# Altium schematic sheet PMIC.SchDoc
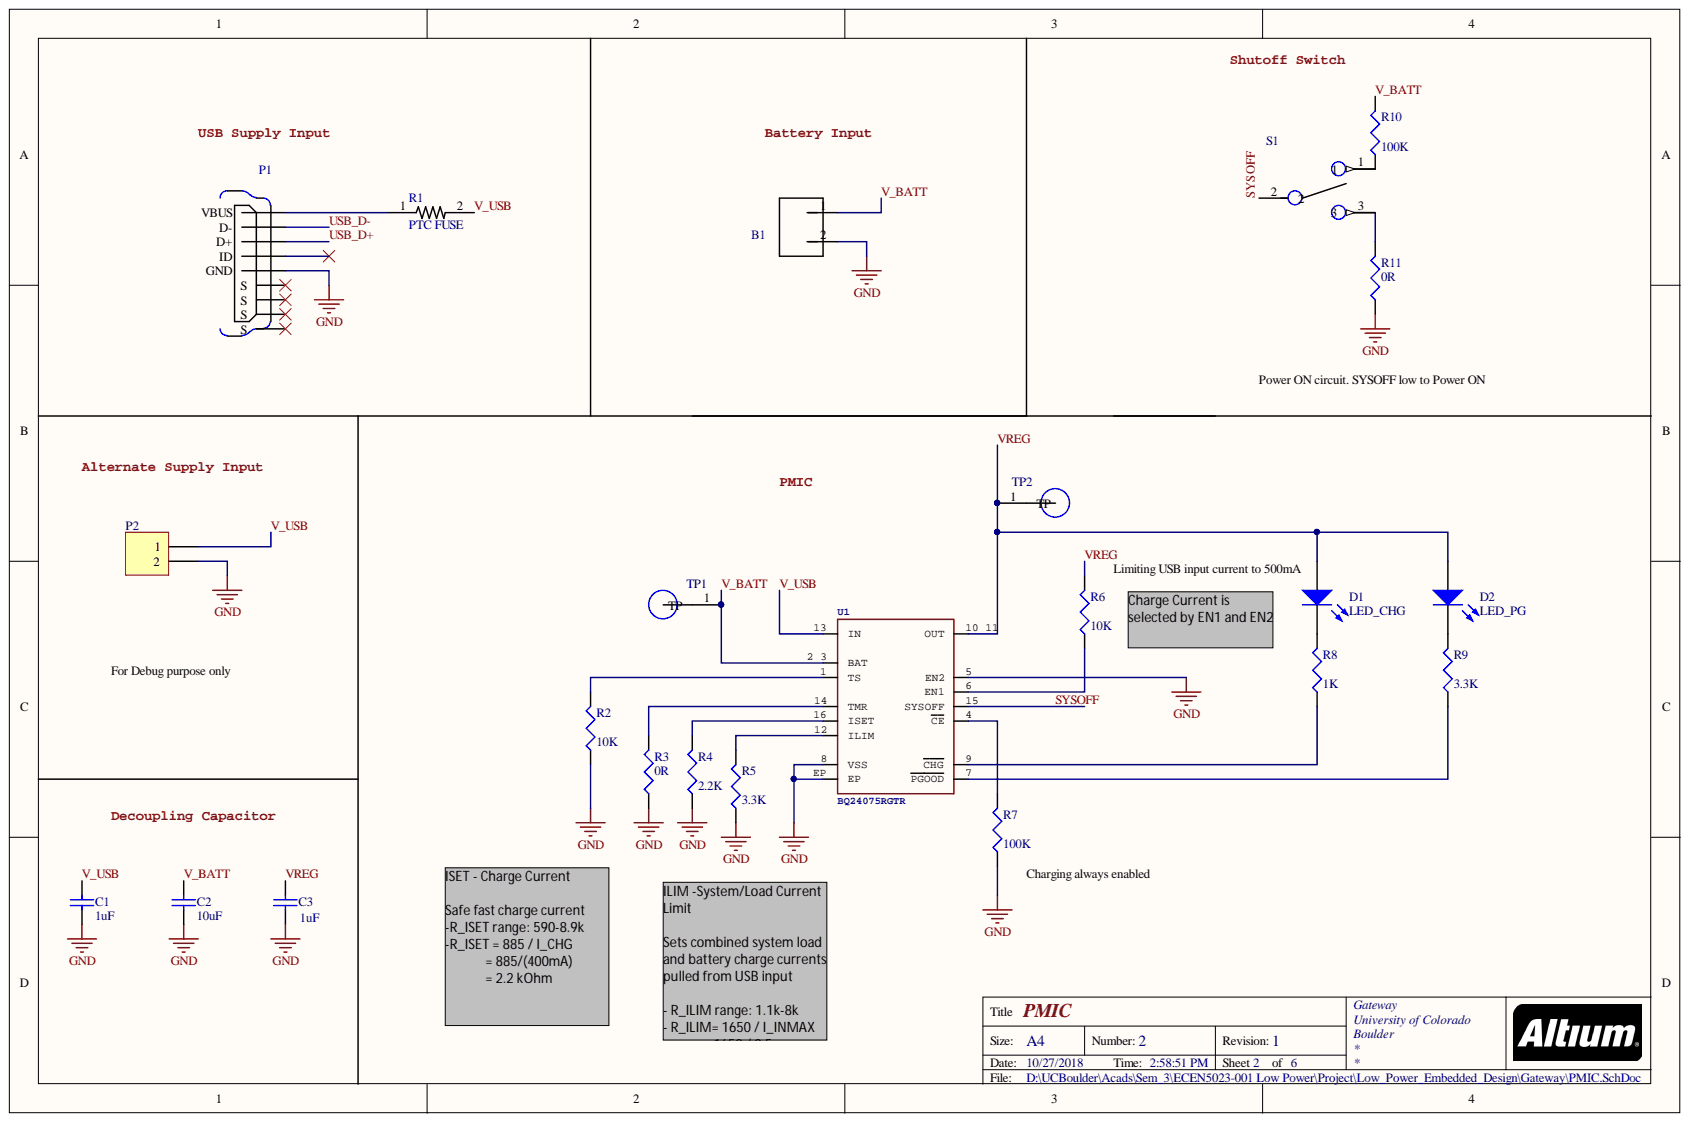
[diagram p0: PMIC.SchDoc]

## Parts
B1  S2B-PH-SM4-TB(LF)(SN)  [S2B-PH-SM4-TB(LF)(SN)]  (value not drawn)
C1  value 1uF  [1608[0603]]  (hidden comment: GCM188R71E104KA57D)
C2  value 10uF  [1608[0603]]  (hidden comment: GRM188R61A106KE69D)
C3  value 1uF  [1608[0603]]  (hidden comment: GCM188R71E104KA57D)
D1  LED_CHG  [3.2X1.6X1.1]
D2  LED_PG  [3.2X1.6X1.1]
P1  10104111-0001LF  [FRAMATOME_10104111-0001LF]  (value not drawn)
P2  Manufacturer Part Number  [HDR1X2]  (value not drawn)
R1  PTC FUSE  [RESC3216X125N]
R2  value 10K  [1608[0603]]  (hidden comment: MCT06030C1002FP500)
R3  value 0R  [1608[0603]]  (hidden comment: MCT0603MZ0000ZP500)
R4  value 2.2K  [1608[0603]]  (hidden comment: ERJ-3EKF2201V)
R5  value 3.3K  [1608[0603]]  (hidden comment: ERJ-3GEYJ332V)
R6  value 10K  [1608[0603]]  (hidden comment: MCT06030C1002FP500)
R7  value 100K  [1608[0603]]  (hidden comment: ERJ-3GEYJ332V)
R8  value 1K  [1608[0603]]  (hidden comment: MCT06030C1001FP500)
R9  value 3.3K  [1608[0603]]  (hidden comment: ERJ-3GEYJ332V)
R10  value 100K  [1608[0603]]  (hidden comment: ERJ-3GEYJ332V)
R11  value 0R  [1608[0603]]  (hidden comment: MCT0603MZ0000ZP500)
S1  AYZ0102AGRLC  [SW_AYZ0102AGRLC]  (value not drawn)
TP1  5019  [TP380X203]  (value not drawn)
TP2  5019  [TP380X203]  (value not drawn)
U1  BQ24075RGTR  [QFN50P300X300X100-17N]

## Nets
GND: B1.2 C1.1 C2.2 C3.1 P1.5 P2.2 R2.1 R3.1 R4.1 R5.1 R7.1 R11.1 U1.5(EN2) U1.8(VSS) U1.EP
SYSOFF: S1.2 U1.15(SYSOFF)
USB_D+: P1.3(D+)
USB_D-: P1.2(D-)
V_BATT: B1.1 C2.1 R10.2 TP1.1 U1.2 3(BAT)
V_USB: C1.2 P2.1 R1.2 U1.13(IN)
VREG: C3.2 D1.1 D2.1 R6.2 TP2.1 U1.10 11(OUT)
NetD1_2: D1.2 R8.2
NetD2_2: D2.2 R9.2
NetP1_1: P1.1 R1.1
NetR2_2: R2.2 U1.1(TS)
NetR3_2: R3.2 U1.14(TMR)
NetR4_2: R4.2 U1.16(ISET)
NetR5_2: R5.2 U1.12(ILIM)
NetR6_1: R6.1 U1.6(EN1)
NetR7_2: R7.2 U1.4(CE)
NetR8_1: R8.1 U1.9(CHG)
NetR9_1: R9.1 U1.7(PGOOD)
NetR10_1: R10.1 S1.1
NetR11_2: R11.2 S1.3

Not connected: P1 4(ID) 6(S) 7(S) 8(S) 9(S)

## Library data of the parts
B1 = S2B-PH-SM4-TB(LF)(SN): CONN HEADER PH SIDE 2POS 2MM SMD; Manufacturer: JST Sales America Inc.; Component Kind: Standard; ComponentLink1Description: Digi-Key URL; ComponentLink1URL: https://www.digikey.com/products/en/connectors-interconnects/rectangular-connectors-headers-male-pins/314?k=S2B-PH-SM4-TB%28LF%29%28SN%29&k=&pkeyword=S2B-PH-SM4-TB%28LF%29%28SN%29&pv7=2&sf=0&quantity=&ColumnSort=0&page=1&pageSize=25; Digi-Key Part Number: 455-1749-1-ND; Library Name: S2B-PH-SM4-TB_LF__SN_.SchLib; Manufacturer Part Number: S2B-PH-SM4-TB(LF)(SN)
C1 C3 = Cap Semi: CAP CER 0.1UF 25V X7R 0603; Manufacturer: Murata Electronics North America; Component Kind: Standard; ComponentLink1Description: Digi-Key Link; ComponentLink1URL: https://www.digikey.com/product-detail/en/murata-electronics-north-america/GCM188R71E104KA57D/490-6051-1-ND/3845251; Digi-Key Part Number: 490-6051-1-ND; Library Name: Miscellaneous Devices.IntLib; Manufacturer Part Number: GCM188R71E104KA57D; Signal Integrity: Cap Semi; Simulation: CAP
C2 = Cap: CAP CER 10UF 10V X5R 0603; Manufacturer: Murata Electronics North America; Component Kind: Standard; ComponentLink1Description: Digi-Key Link; ComponentLink1URL: https://www.digikey.com/product-detail/en/murata-electronics-north-america/GRM188R61A106KE69D/490-10474-1-ND/5026392; Digi-Key Part Number: 490-10474-1-ND; LatestRevisionDate: 17-Jul-2002; LatestRevisionNote: Re-released for DXP Platform.; Library Name: Miscellaneous Devices.IntLib; Manufacturer Part Number: GRM188R61A106KE69D; PackageDescription: Radial Cap, Thru-Hole; 2 Leads; 0.3 in Pin Spacing; PackageReference: RAD-0.3; Published: 8-Jun-2000; Signal Integrity: Cap; Simulation: CAP; Publisher: Altium Limited
D1 = LED2: Blue 470nm LED Indication - Discrete 2.85V 0603 (1608 Metric); Manufacturer: OSRAM Opto Semiconductors Inc.; Manufacturer Part Number: LB Q39G-L2OO-35-1; Component Kind: Standard; ComponentLink1Description: Digi-Key Link; ComponentLink1URL: https://www.digikey.com/product-detail/en/osram-opto-semiconductors-inc/LB-Q39G-L2OO-35-1/475-2816-1-ND/2176355; Digi-Key Part Number: 475-2816-1-ND; Library Name: Miscellaneous Devices.IntLib; Simulation: LED2
D2 = LED2: LED GREEN DIFFUSED 0603 SMD; Manufacturer: OSRAM Opto Semiconductors Inc.; Manufacturer Part Number: LG L29K-F2J1-24-Z; Component Kind: Standard; ComponentLink1Description: Digi-Key Link; ComponentLink1URL: https://www.digikey.com/product-detail/en/osram-opto-semiconductors-inc/LG-L29K-F2J1-24-Z/475-3118-1-ND/4899549; Digi-Key Part Number: 475-3118-1-ND; Library Name: Miscellaneous Devices.IntLib; Simulation: LED2
P1 = 10104111-0001LF: Micro Usb, 2.0 Type Ab, Receptacle, Th; Manufacturer: Amphenol FCI; Component Kind: Standard; ComponentLink1Description: Digi-Key Link; ComponentLink1URL: https://www.digikey.com/product-detail/en/amphenol-fci/10104111-0001LF/609-4053-1-ND/2350359?utm_source=snapeda&utm_medium=aggregator&utm_campaign=symbol; Digi-Key Part Number: 609-4053-1-ND; Library Name: USB-connector.SchLib; Manufacturer Part Number: 10104111-0001LF
P2 = Header 2: Header, 2-Pin; Component Kind: Standard; Library Name: Miscellaneous Connectors.IntLib; Signal Integrity: Connector
R1 = 0ZCA0075FF2G: PTC RESET FUSE 6V 500MA 1206; Manufacturer: Bel Fuse; Manufacturer Part Number: Littelfuse Inc.; Availability: Available; Component Kind: Standard; ComponentLink1Description: Digi-Key Link; ComponentLink1URL: https://www.digikey.com/product-detail/en/littelfuse-inc/1206L050YR/F2112CT-ND/455721; Digi-Key Part Number: F2112CT-ND; Library Name: PTC-1206.SchLib; MP: 0ZCA0075FF2G; Package: 1206 Bel Fuse; Price: None; SnapEDA_Link: https://www.snapeda.com/parts/0ZCA0075FF2G/Bel%20Fuse%20Inc./view-part/?ref=snap
R2 R6 = Res3: RES SMD 10K OHM 1% 1/8W 0603; Manufacturer: Vishay Beyschlag; Component Kind: Standard; ComponentLink1Description: Digi-Key URL; ComponentLink1URL: https://www.digikey.com/products/en?keywords=MCT0603-10.0K-CFCT-ND; Digi-Key Part Number: MCT0603-10.0K-CFCT-ND; Library Name: Miscellaneous Devices.IntLib; Manufacturer Part Number: MCT06030C1002FP500; Signal Integrity: Res; Simulation: RESISTOR
R3 R11 = Res3: RES 0 OHM JUMPER 1/8W 0603; Manufacturer: Vishay Beyschlag; Component Kind: Standard; ComponentLink1URL: https://www.digikey.com/product-detail/en/vishay-beyschlag/MCT0603MZ0000ZP500/749-1745-1-ND/7348053; Digi-Key Part Number: 749-1745-1-ND; LatestRevisionDate: 29-May-2009; LatestRevisionNote: IPC-7351 Footprint Added.; Library Name: Miscellaneous Devices.IntLib; Manufacturer Part Number: MCT0603MZ0000ZP500; PackageDescription: Chip Resistor; PackageReference: J1-0603; Published: 8-Jun-2000; Signal Integrity: Res; Simulation: RESISTOR; Publisher: Altium Limited
  R3: ComponentLink1Description: Digi-Key Link
  R11: ComponentLink2Description: Digi-Key Link
R4 = Res3: RES SMD 2.2K OHM 1% 1/10W 0603; Manufacturer: Panasonic Electronic Components; Component Kind: Standard; ComponentLink1Description: Digi-Key URL; ComponentLink1URL: https://www.digikey.com/product-detail/en/panasonic-electronic-components/ERJ-3EKF2201V/P2.20KHCT-ND/1746742; Digi-Key Part Number: P2.20KHCT-ND; Library Name: Miscellaneous Devices.IntLib; Manufacturer Part Number: ERJ-3EKF2201V; Signal Integrity: Res; Simulation: RESISTOR
R5 R7 R9 R10 = Res3: RES SMD 3.3K OHM 5% 1/10W 0603; Manufacturer: Panasonic Electronic Components; Component Kind: Standard; ComponentLink1Description: Digi-Key URL; ComponentLink1URL: https://www.digikey.com/product-detail/en/panasonic-electronic-components/ERJ-3GEYJ332V/P3.3KGCT-ND/135146; Digi-Key Part Number: P3.3KGCT-ND; Library Name: Miscellaneous Devices.IntLib; Manufacturer Part Number: ERJ-3GEYJ332V; Signal Integrity: Res; Simulation: RESISTOR
R8 = Res3: RES SMD 1K OHM 1% 1/8W 0603; Manufacturer: Vishay Beyschlag; Component Kind: Standard; ComponentLink1Description: Digi-Key URL; ComponentLink1URL: https://www.digikey.com/product-detail/en/vishay-beyschlag/MCT06030C1001FP500/MCT0603-1.00K-CFCT-ND/2607875; Digi-Key Part Number: MCT0603-1.00K-CFCT-ND; Library Name: Miscellaneous Devices.IntLib; Manufacturer Part Number: MCT06030C1001FP500; Signal Integrity: Res; Simulation: RESISTOR
S1 = AYZ0102AGRLC: SWITCH SLIDE SPDT 100MA 12V; Manufacturer: C&K; Component Kind: Standard; ComponentLink1Description: Digi-Key Link; ComponentLink1URL: https://www.digikey.com/products/en/switches/slide-switches/213?k=AYZ0102AGRLC&k=&pkeyword=AYZ0102AGRLC&pv7=2&sf=0&quantity=&ColumnSort=0&page=1&pageSize=25; Digi-Key Part Number: 401-2012-1-ND; Library Name: AYZ0102AGRLC.SchLib; Manufacturer Part Number: AYZ0102AGRLC
TP1 TP2 = 5019: PC TEST POINT MINIATURE; Manufacturer: Keystone Electronics; MF: Keystone; Component Kind: Standard; ComponentLink1Description: Digi-Key Link; ComponentLink1URL: https://www.digikey.com/product-detail/en/keystone-electronics/5019/36-5019CT-ND/3907344?utm_source=snapeda&utm_medium=aggregator&utm_campaign=symbol; Digi-Key_Part_Number: 36-5019CT-ND; Digi-Key Part Number: 36-5019CT-ND; Library Name: 5019.IntLib; Manufacturer Part Number: 5019; MP: 5019; Package: 2010 Keystone; SnapEDA_Link: https://www.snapeda.com/parts/5019/Keystone%20Electronics/view-part/?ref=snap
U1 = BQ24075: IC LI-ION CHARGE MGMT 16-QFN; Manufacturer: Texas Instruments; Component Kind: Standard; ComponentLink1Description: Digi-Key Link; ComponentLink1URL: https://www.digikey.com/products/en/integrated-circuits-ics/pmic-battery-chargers/781?k=BQ24075RGTR&k=&pkeyword=BQ24075RGTR&pv7=2&sf=0&quantity=&ColumnSort=0&page=1&pageSize=25; Digi-Key Part Number: 296-38874-1-ND; Library Name: SparkFun-PowerIC.IntLib; Manufacturer Part Number: BQ24075RGTR
